# Altium schematic sheet SENSORS.SchDoc(SENSORS)
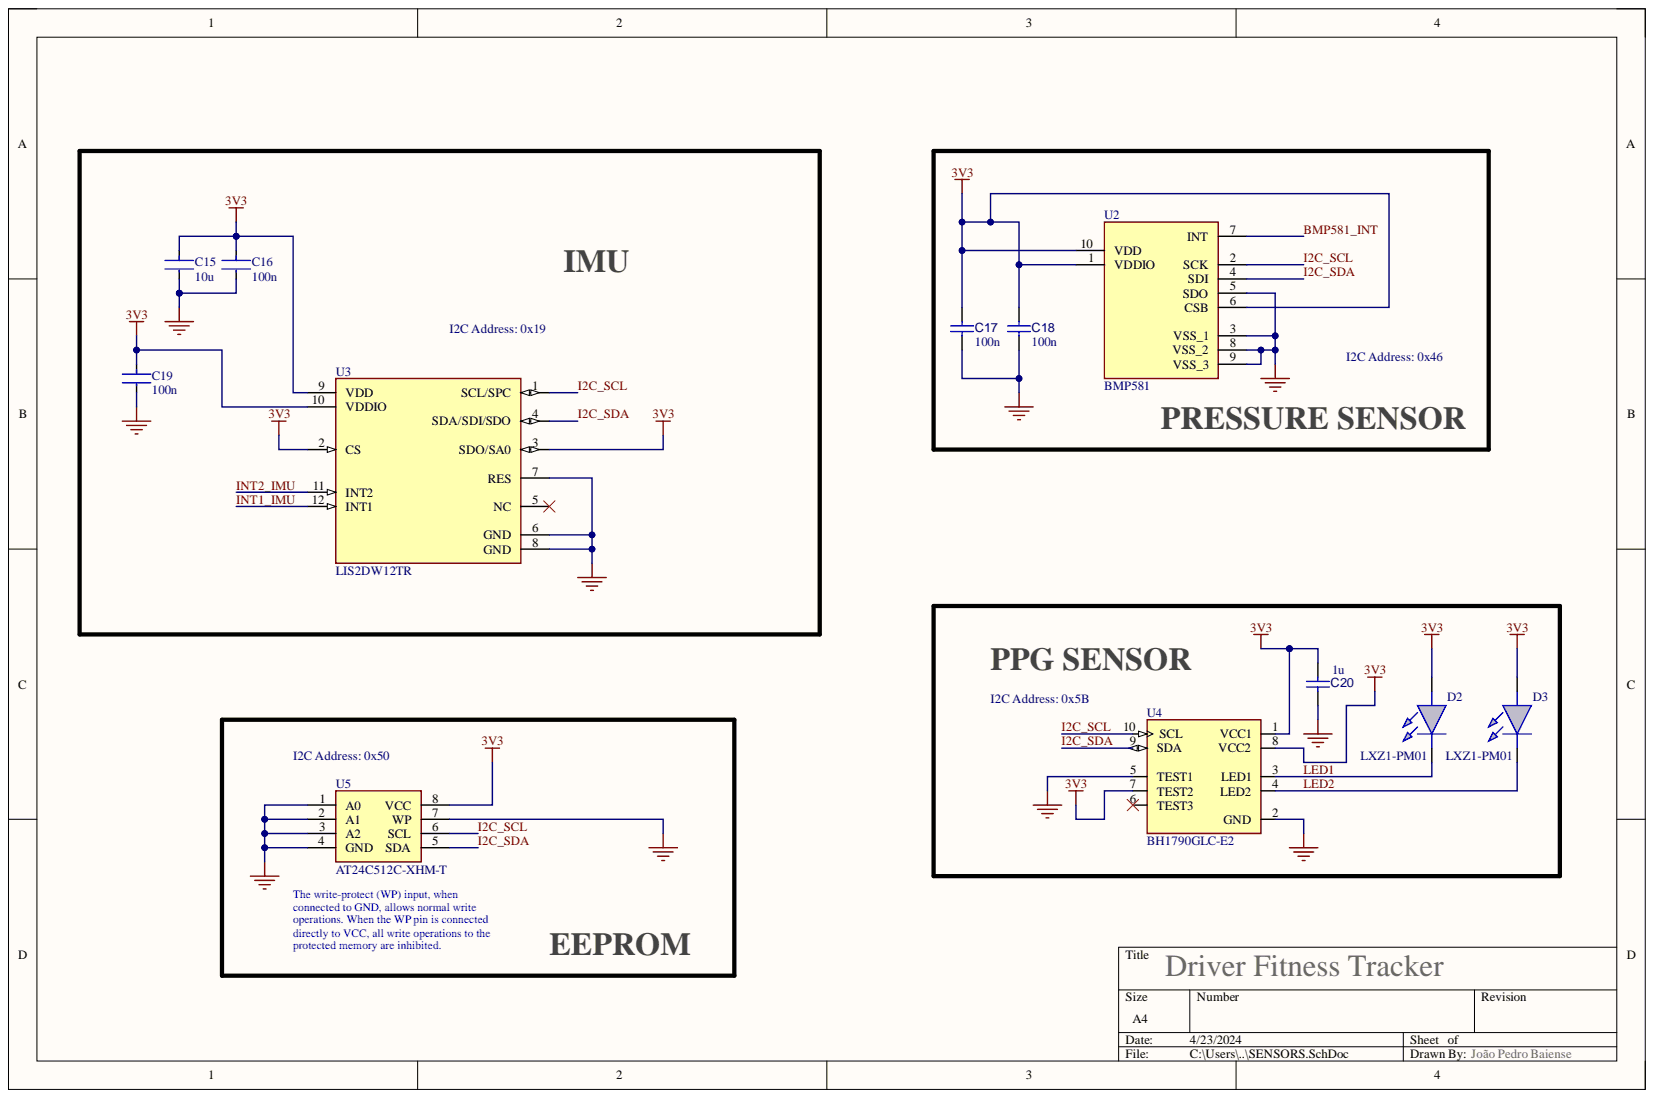
[diagram p0: SENSORS.SchDoc]

## Parts
C15  10u  [FP-C0402-BB-MFG]
C16  100n  [FP-C0402-BB-MFG]
C17  100n  [CAPC1608X90X40NL10T20]
C18  100n  [CAPC1608X90X40NL10T20]
C19  100n  [FP-C0402-BB-MFG]
C20  1u  [CAPC1608X90X40NL10T20]
D2  LXZ1-PM01  [LEDC1608X70N]
D3  LXZ1-PM01  [LEDC1608X70N]
U2  BMP581  [BMP581]
U3  LIS2DW12TR  [FP-LGA12-DM00170568-MFG]
U4  BH1790GLC-E2  [PQFN70P280X280X100-10N]
U5  AT24C512C-XHM-T  [SOP65P640X120-8N]

## Nets
3V3: C15.1 C16.1 C17.2 C18.2 C19.1 C20.1 D2.2 D3.2 U2.1(VDDIO) U2.6(CSB) U2.10(VDD) U3.2(CS) U3.3(SDO/SA0) U3.9(VDD) U3.10(VDDIO) U4.1(VCC1) U4.7(TEST2) U4.8(VCC2) U5.8(VCC)
GND: C15.2 C16.2 C17.1 C18.1 C19.2 C20.2 U2.3(VSS_1) U2.5(SDO) U2.8(VSS_2) U2.9(VSS_3) U3.6(GND) U3.7(RES) U3.8(GND) U4.2(GND) U4.5(TEST1) U5.1(A0) U5.2(A1) U5.3(A2) U5.4(GND) U5.7(WP)
BMP581_INT: U2.7(INT)
I2C_SCL: U2.2(SCK) U3.1(SCL/SPC) U4.10(SCL) U5.6(SCL)
I2C_SDA: U2.4(SDI) U3.4(SDA/SDI/SDO) U4.9(SDA) U5.5(SDA)
INT1_IMU: U3.12(INT1)
INT2_IMU: U3.11(INT2)
LED1: D2.1 U4.3(LED1)
LED2: D3.1 U4.4(LED2)

Not connected: U3 5(NC); U4 6(TEST3)

## Library data of the parts
C15 = CMP-2008-02046-2: Multilayer Ceramic Capacitors MLCC - SMD/SMT 10 uF 6.3 VDC 20% 0402 X5R; CorrectManufacturer: GRM155R60J106ME05D; Manufacturer: Kemet; Capacitance: 0.10 μF; Temperature Coefficient: 15%; Tolerance: 0.1; Value: 0.10 μF; Voltage Rating: 10 V; Automotive: No; Category: Cap; Component Kind: Standard; ComponentLink2Description: Datasheet; ComponentLink2URL: https://content.kemet.com/datasheets/KEM_C1002_X7R_SMD.pdf; Device Class L1: Passive Components; Device Class L2: Capacitors; Device Class L3: Ceramic Capacitors; Digikey Description: CAP CER 0.1UF 10V X5R 0402; Height: 0.55mm; Ipc Land Pattern Name: CAPC10050X50; JLCPCB Part #: C19702; Lead Free: Yes; Library Name: Altium Content Vault; Material: Ceramic; Mouser Description: Capacitor 100 nF 10 VDC 0402; Octopart: https://octopart.com/c0402c104k8pactu-kemet-140039; Package: C0402-BB; RoHS: TRUE; Temperature: -55°C to +125°C; Temperature Characteristic: X5R; Temperature Range High: +125°C; Temperature Range Low: -55°C
C16 C19 = CMP-2008-02046-2: Multilayer Ceramic Capacitors MLCC - SMD/SMT NEW GLOBAL PN KGM05CR71H104KN 50V 0.1uF X7R 0402 10%; CorrectManufacturer: 04025C104KAT4A; Manufacturer: Kemet; Capacitance: 0.10 μF; Temperature Characteristic: X5R; Temperature Coefficient: 15%; Tolerance: 0.1; Value: 0.10 μF; Voltage Rating: 10 V; Automotive: No; Category: Cap; Component Kind: Standard; ComponentLink2Description: Datasheet; ComponentLink2URL: https://content.kemet.com/datasheets/KEM_C1002_X7R_SMD.pdf; Device Class L1: Passive Components; Device Class L2: Capacitors; Device Class L3: Ceramic Capacitors; Digikey Description: CAP CER 0.1UF 10V X5R 0402; Height: 0.55mm; Ipc Land Pattern Name: CAPC10050X50; JLCPCB Part #: C1523; Lead Free: Yes; Library Name: Altium Content Vault; Material: Ceramic; Mouser Description: Capacitor 100 nF 10 VDC 0402; Octopart: https://octopart.com/c0402c104k8pactu-kemet-140039; Package: C0402-BB; RoHS: TRUE; Temperature: -55°C to +125°C; Temperature Range High: +125°C; Temperature Range Low: -55°C
C17 C18 = CMP-001-00017-6: Multilayer Ceramic Capacitors MLCC - SMD/SMT 50V 0.1 uF X7R 0603 10% AEC-Q200; CorrectManufacturer: KAM15AR71H104KT; Capacitor Type: Ceramic; Case/Package: 0603; Component Kind: Standard; JLCPCB Part #: C1523; Max Operating Temperature: 125°C; Mounting Technology: SM; Pins: 2; RoHS Compliant: True; Tolerance: 10%; Value: 100n; Voltage Rating: 50V; Library Name: Tese_project
C20 = CMP-001-00017-6: Multilayer Ceramic Capacitors MLCC - SMD/SMT 50V 1uF X7R 0603 10%; CorrectManufacturer: 06035C105KAT2A; Capacitor Type: Ceramic; Case/Package: 0603; Component Kind: Standard; JLCPCB Part #: C15849; Max Operating Temperature: 125°C; Mounting Technology: SM; Pins: 2; RoHS Compliant: True; Tolerance: 10%; Value: 1u; Voltage Rating: 50V; Library Name: Tese_project
D2 = LED_19-217/GHC-YR1S2/3T: Standard LEDs - SMD Brilliant Green Everlight; Geometry.Height: 0.96mm; Available at JLCPCB?: Yes; Component Kind: Standard; CorrectManufacturer: 19-217/GHC-YR1S2/3T; Datasheet Link: https://pt.mouser.com/datasheet/2/143/19-217-GHC-YR1S2-3T-1663276.pdf; JLCPCB Link: https://jlcpcb.com/partdetail/EverlightElec-19_217_GHC_YR1S23T/C72043; JLCPCB Part #: C72043; Manufacturer_Name: Everlight; Mouser Part Number: 638-19217GHCYR1S23T; Mouser Price/Stock: https://pt.mouser.com/ProductDetail/Everlight/19-217-GHC-YR1S2-3T?qs=8cKuZ6Ok2lZjmFTUzVFjDg%3D%3D; Library Name: Tese_SymbolLib.SchLib
D3 = LED_19-217/GHC-YR1S2/3T: High Power LEDs - Single Color Green; Manufacturer_Name: Everlight; Geometry.Height: 0.96mm; Available at JLCPCB?: Yes; Component Kind: Standard; CorrectManufacturer: 19-217/GHC-YR1S2/3T; Datasheet Link: https://pt.mouser.com/datasheet/2/143/19-217-GHC-YR1S2-3T-1663276.pdf; JLCPCB Link: https://jlcpcb.com/partdetail/EverlightElec-19_217_GHC_YR1S23T/C72043; JLCPCB Part #: C72043; Mouser Part Number: 638-19217GHCYR1S23T; Mouser Price/Stock: https://pt.mouser.com/ProductDetail/Everlight/19-217-GHC-YR1S2-3T?qs=8cKuZ6Ok2lZjmFTUzVFjDg%3D%3D; Library Name: Tese_SymbolLib.SchLib
U2 = BMP581: Board Mount Pressure Sensors The BMP581 is a very small, low-power and low-noise 24-bit absolute barometric pressure sensor; Manufacturer_Name: BOSCH; Geometry.Height: 0.8mm; Component Kind: Standard; CorrectManufacturer: BMP581; Datasheet Link: https://www.bosch-sensortec.com/media/boschsensortec/downloads/datasheets/bst-bmp581-ds004.pdf; Mouser Part Number: 262-BMP581; Mouser Price/Stock: https://www.mouser.co.uk/ProductDetail/Bosch-Sensortec/BMP581?qs=Li%252BoUPsLEntPL9tlFmcgXg%3D%3D; Library Name: Tese_SymbolLib.SchLib
U3 = CMP-12175-000006-1: ACCEL 2-16G I2C/SPI 12LGA STMicroelectronics; Accel Range: 2/4/8/16g; Accel Resolution: 14bits; Max Supply Voltage: 3.6V; Min Supply Voltage: 1.62V; Operating Supply Current: 0.38-90uA; Sensor Output: I2C,SPI; Automotive: No; Category: IC; Component Kind: Standard; ComponentLink2Description: Datasheet; ComponentLink2URL: https://www.st.com/resource/en/datasheet/lis2dw12.pdf; CorrectManufacturer: LIS2DW12TR; Device Class L1: Sensors; Device Class L2: Motion Sensors; Device Class L3: Accelerometers; Digikey Description: ACCEL 2-16G I2C/SPI 12LGA; Footprint Url: https://www.st.com/content/ccc/resource/technical/document/technical_note/73/17/9c/16/dd/96/4a/01/CD00134799.pdf/files/CD00134799.pdf/jcr:content/translations/en.CD00134799.pdf; Height: 0.7mm; JLCPCB Link: https://jlcpcb.com/partdetail/Stmicroelectronics-LIS2DW12TR/C189624; JLCPCB Part #: C189624; Lead Free: Yes; Manufacturer: STMicroelectronics; Mouser Description: Accelerometer Triple ±2g/±4g/±8g/±16g 1.62V to 3.6V 0.244mg/digit/0.488mg/digit/0.976mg/digit/1.952mg/digit 12-Pin LG...; Octopart: https://octopart.com/lis2dw12tr-stmicroelectronics-77080925; Package: LGA12-DM00170568; RoHS: TRUE; Temperature: -40°C to +85°C; Temperature Range High: +85°C; Temperature Range Low: -40°C; Library Name: Tese_SymbolLib.SchLib
U4 = BH1790GLC-E2: Light To Frequency & Light To Voltage Opt Sensr Heart Rate Monitor IC 200uA; MF: Rohm; Availability: Warning; Available at JLCPCB?: No; Component Kind: Standard; CorrectManufacturer: BH1790GLC-E2; JLCPCB Link: None; Mouser-Purchase-URL: https://snapeda.com/shop?store=Mouser&id=2761766; Package: LGA-10 Rohm; Price: None; SnapEDA_Link: https://www.snapeda.com/parts/BH1790GLC-E2/Rohm/view-part/2761766/?ref=snap; Library Name: Tese_SymbolLib.SchLib
U5 = AT24C512C-XHM-T: EEPROM SERIAL EEPROM 512K (64K X 8) 2WIRE 1.7V; Manufacturer_Name: Microchip; Geometry.Height: 1.2mm; Available at JLCPCB?: YES; Component Kind: Standard; CorrectManufacturer: AT24C512C-XHM-T; Datasheet Link: https://ww1.microchip.com/downloads/en/DeviceDoc/20006161B.pdf; JLCPCB Linkl: https://jlcpcb.com/partdetail/MicrochipTech-AT24C512C_XHMT/C111546; JLCPCB Part #: C111546; Mouser Part Number: 556-AT24C512C-XHM-T; Mouser Price/Stock: https://www.mouser.co.uk/ProductDetail/Microchip-Technology/AT24C512C-XHM-T?qs=rNaVQffb%252BteaIrq7vigEzw%3D%3D; Library Name: Tese_SymbolLib.SchLib
